# Altium schematic sheet Filter.SchDoc
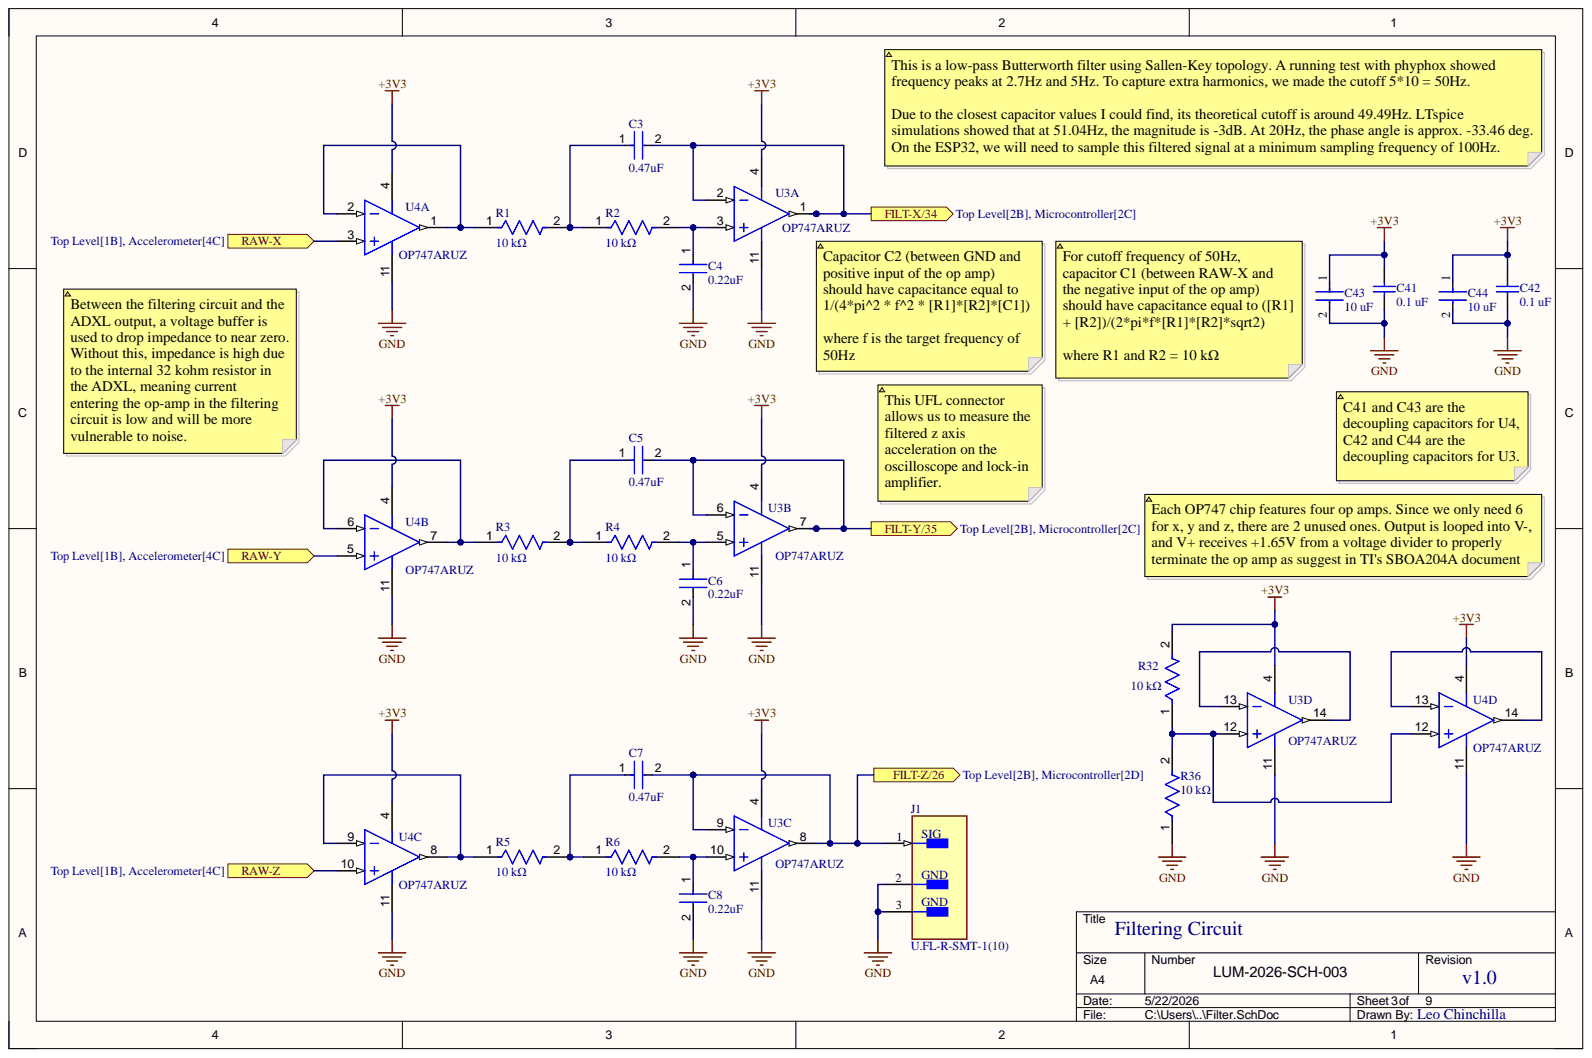
[diagram p0: Filter.SchDoc]

## Parts
C3  0.47uF  [FP-CC0603-DA-MFG]
C4  0.22uF  [FP-CC0603-DA-MFG]
C5  0.47uF  [FP-CC0603-DA-MFG]
C6  0.22uF  [FP-CC0603-DA-MFG]
C7  0.47uF  [FP-CC0603-DA-MFG]
C8  0.22uF  [FP-CC0603-DA-MFG]
C41  0.1 uF  [CAPC1608X90X35NL15T15]
C42  0.1 uF  [CAPC1608X90X35NL15T15]
C43  10 uF  [FP-CC0603-DC-MFG]
C44  10 uF  [FP-CC0603-DC-MFG]
J1  U.FL-R-SMT-1(10)  [FP-U_FL-R-SMT-1_10-MFG]
R1  10 kΩ  [FP-MCT0603-IPC_B]
R2  10 kΩ  [FP-MCT0603-IPC_B]
R3  10 kΩ  [FP-MCT0603-IPC_B]
R4  10 kΩ  [FP-MCT0603-IPC_B]
R5  10 kΩ  [FP-MCT0603-IPC_B]
R6  10 kΩ  [FP-MCT0603-IPC_B]
R32  10 kΩ  [FP-AC0603-IPC_A]
R36  10 kΩ  [FP-AC0603-IPC_A]
U3  OP747ARUZ  [ADI-RU-14_N]  (parts A,B,C,D)
U4  OP747ARUZ  [ADI-RU-14_N]  (parts A,B,C,D)

## Ports
FILT-X/34, FILT-Y/35, FILT-Z/26, RAW-X, RAW-Y, RAW-Z

## Nets
+3V3: C41.2 C42.2 C43.1 C44.1 R32.2 U3.4 U4.4
GND: C4.2 C6.2 C8.2 C41.1 C42.1 C43.2 C44.2 J1.2 J1.3 R36.1 U3.11 U4.11
FILT-X/34: C3.2 U3.1 U3.2 ; port FILT-X/34
FILT-Y/35: C5.2 U3.6 U3.7 ; port FILT-Y/35
FILT-Z/26: C7.2 J1.1 U3.8 U3.9 ; port FILT-Z/26
RAW-X: U4.3 ; port RAW-X
RAW-Y: U4.5 ; port RAW-Y
RAW-Z: U4.10 ; port RAW-Z
NetC3_1: C3.1 R1.2 R2.1
NetC4_1: C4.1 R2.2 U3.3
NetC5_1: C5.1 R3.2 R4.1
NetC6_1: C6.1 R4.2 U3.5
NetC7_1: C7.1 R5.2 R6.1
NetC8_1: C8.1 R6.2 U3.10
NetR1_1: R1.1 U4.1 U4.2
NetR3_1: R3.1 U4.6 U4.7
NetR5_1: R5.1 U4.8 U4.9
NetR32_1: R32.1 R36.2 U3.12 U4.12
NetU3_13: U3.13 U3.14
NetU4_13: U4.13 U4.14

## Library data of the parts
C3 C5 C7 = CMP-03422-000470-1: CAP CER 0.47UF 50V X5R 0603; Manufacturer: YAGEO; Manufacturer Part Number: CC0603KRX5R9BB474; Capacitance: 470 nF; Material: Ceramic; Temperature Coefficient: 15%; Tolerance: 0.1; Value: 470 nF; Automotive: No; Category: Cap; Component Kind: Standard; ComponentLink2Description: Datasheet; ComponentLink2URL: http://www.yageo.com/documents/recent/UPY-GPHC_X7R_6.3V-to-50V_18.pdf; Device Class L1: Passive Components; Device Class L2: Capacitors; Device Class L3: Ceramic Capacitors; Digikey Description: CAP CER 0.47UF 50V X5R 0603; Footprint Url: http://www.yageo.com/exep/pages/download/literatures/UPY-C_GEN_24.pdf; Height: 0.9mm; Lead Free: Yes; Library Name: Altium Content Vault; Mouser Description: Multilayer Ceramic Capacitors MLCC - SMD/SMT .47uF 50V 10% 0603 Case Size; Octopart: https://octopart.com/cc0603krx5r9bb474-yageo-71334480; Package: CC0603-DA; RoHS: TRUE; Temperature: -55°C to +125°C; Temperature Characteristic: X5R; Temperature Range High: +125°C; Temperature Range Low: -55°C; Voltage: 50 V; Voltage Rating: 50 V
C4 C6 C8 = CMP-03422-000390-1: CC0603 220 nF X7R 10.00% 25 V; Manufacturer: YAGEO; Manufacturer Part Number: CC0603KPX7R8BB224; Capacitance: 220 nF; Material: Ceramic; Temperature Coefficient: 15%; Tolerance: 0.1; Value: 220 nF; Voltage: 25 V; Automotive: No; Category: Cap; Component Kind: Standard; ComponentLink2Description: Datasheet; ComponentLink2URL: http://www.yageo.com/documents/recent/UPY-GPHC_X7R_6.3V-to-50V_18.pdf; Device Class L1: Passive Components; Device Class L2: Capacitors; Device Class L3: Ceramic Capacitors; Footprint Url: http://www.yageo.com/exep/pages/download/literatures/UPY-C_GEN_24.pdf; Height: 0.9mm; Lead Free: Yes; Library Name: Altium Content Vault; Mouser Description: Cap Ceramic 0.22uF 25VDC X7R 10% SMD 0603 125°C Paper T/R; Octopart: https://octopart.com/cc0603kpx7r8bb224-yageo-30511392; Package: CC0603-DA; RoHS: TRUE; Temperature: -55°C to +125°C; Temperature Characteristic: X7R; Temperature Range High: +125°C; Temperature Range Low: -55°C; Voltage Rating: 25 V
C41 C42 = CMP-2006-02299-1: Manufacturer: Kemet; Part Number: C0603C104K4RAC7013; Component Kind: Standard; Library Name: Altium Content Vault
C43 C44 = CMP-00621-81932632-1: CC0603KRX5R7BB106 Multilayer Ceramic Capacitor, 10 uF, 16 V, ± 10%, X5R, 0603 [1608 Metric]; Capacitance: 10µF; Voltage Rating: 16V; Case/Package: 0603; Component Kind: Standard; Dielectric: X5R; Halogen Free: Halogen Free; Height: 1mm; Lead Free: Lead Free; Library Name: Altium Content Vault; Material: Ceramic; Max Operating Temperature: 85°C; Min Operating Temperature: -55°C; NexarPartId: 81932632; RoHS: Non-Compliant; Tolerance: 10%; Voltage Rating (DC): 16V
J1 = CMP-2000-05152-3: CONN U.FL RCPT STR 50 OHM SMD; Body Material: Bronze; Body Plating: Silver; Connector Type: Coaxial; Dielectric Material: Polymer; Frequency: 6GHz; Gender: Male; Impedance: 50R; Insulation Resistance: 500MR; Component Kind: Standard; Contact Material: Brass; Contact Plating: Gold; Depth: 3.1mm; Fastening Type: Snap; Housing Color: Beige; Lead Free: Lead Free; Length: 3mm; Library Name: Altium Content Vault; Max Frequency: 6GHz; Max Operating Temperature: 90°C; Min Operating Temperature: -40°C; Mount: Surface Mount; Orientation: Straight; Package Quantity: 2500; Packaging: Cut Tape; Radiation Hardening: No; REACH SVHC: No SVHC; Series: U.FL; Termination: Solder; Weight: 0.001764oz; Wire/Cable Type: Coaxial
R1-R6 = CMP-02407-001090-1: RES SMD 100 OHM 1% 1/8W 0603; Component Kind: Standard; Library Name: Altium Content Vault
R32 R36 = CMP-03412-040683-1: RES 10kΩ 5.00% 0.2W 0603; Component Kind: Standard; Library Name: Altium Content Vault
U3 U4 = CMP-0384-01863-1: Quad Channel Rail-to-Rail Operational Amplifier, 700 KHz BW, 200 mV/us SR, Industrial, 14-pin SOP (RU-14), Reel; Generic Part Number: OP747; Manufacturer: Analog Devices; PartNumber: OP747ARUZ-REEL; Amplifiers Per Package: 4; Input Bias Current: 5.5nA; Input Offset Voltage: 30uV; Iq/Amp: 290uA; Small Signal Bandwidth: 700KHz; V Span Max: 36V; V Span Min: 3V; Code_JEDEC: MO-153AB-1; Component Kind: Standard; ComponentLink1Description: Manufacturer URL; ComponentLink1URL: http://www.analog.com/en/index.html; ComponentLink2Description: Datasheet; ComponentLink2URL: http://www.analog.com/static/imported-files/data_sheets/OP777_727_747.pdf; ComponentLink3Description: Package Specification; ComponentLink3URL: http://www.analog.com/static/imported-files/packages/36057385283879153717235186773RU14.pdf; DatasheetVersion: Rev. D; Library Name: Altium Content Vault; Mounting Technology: Surface Mount; PackageDescription: 14-Pin Thin Shrink Small Outline Package, Body 5 x 4.4 mm, Pitch 0.65 mm; PackageReference: RU-14; Slew Rate: 200mV/us
